# Altium schematic sheet 05_ATK_DLRK3506J_NET0.SchDoc
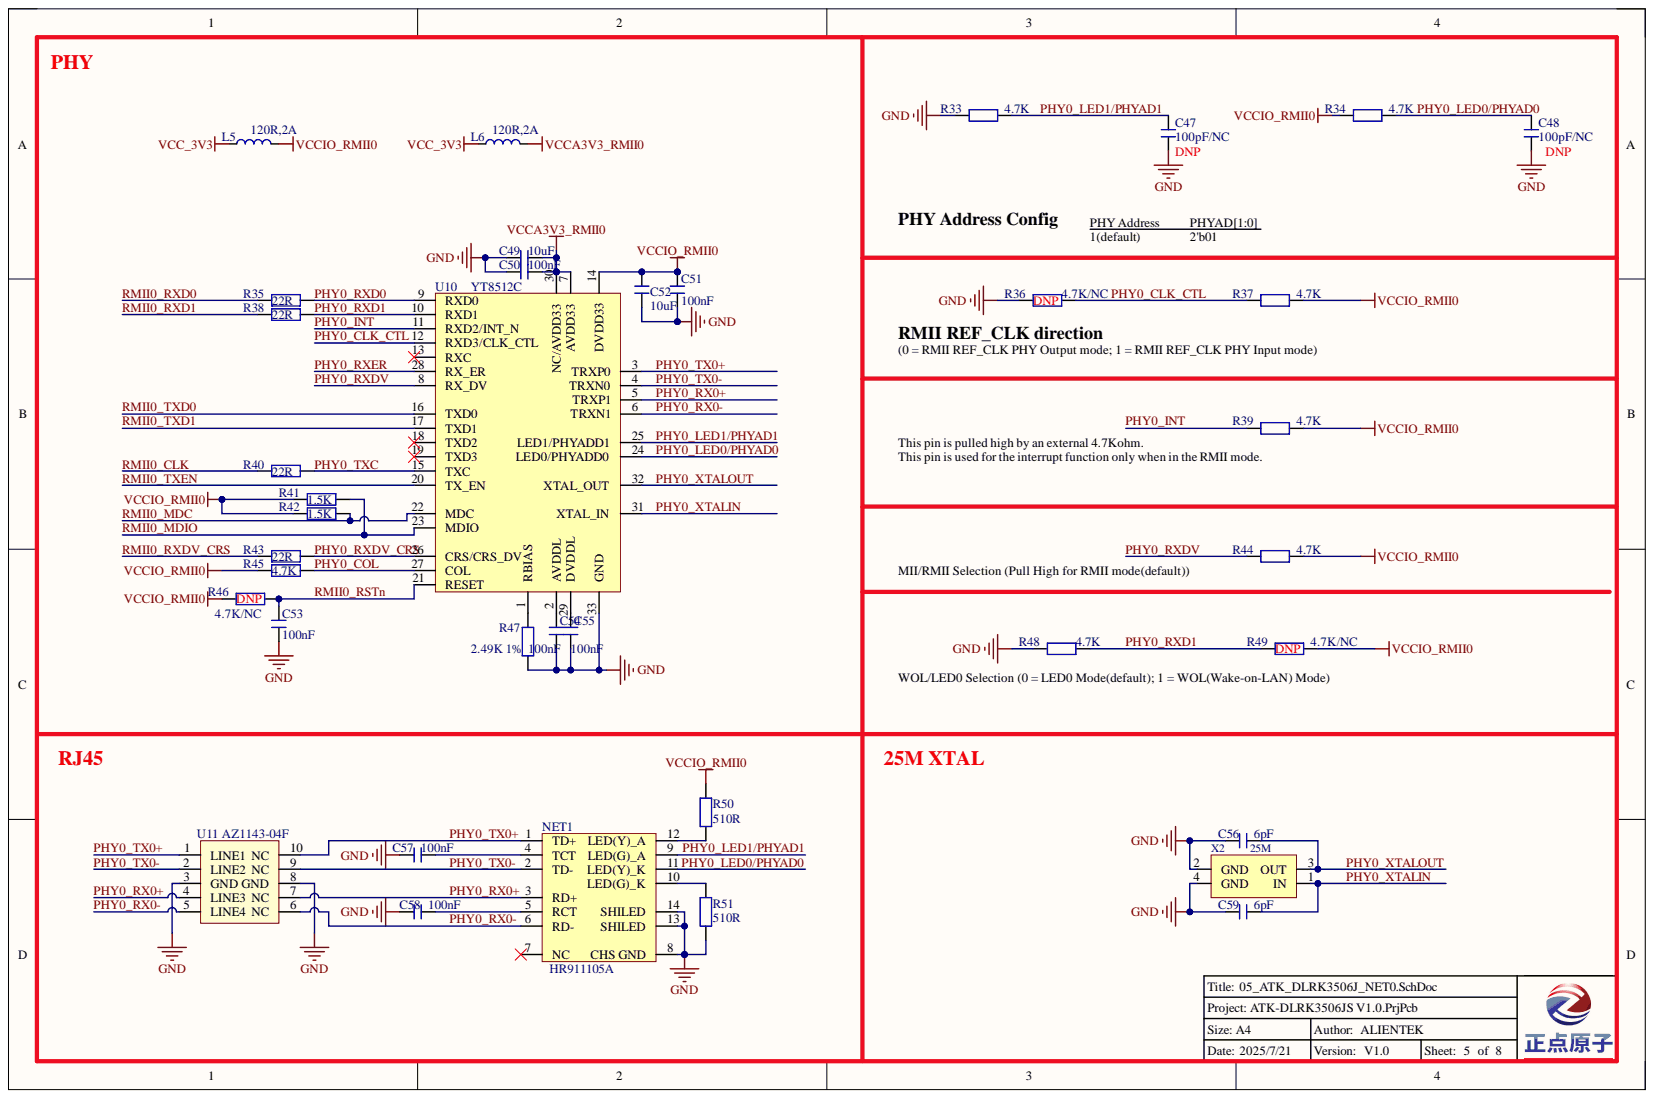
[diagram p0: 05_ATK_DLRK3506J_NET0.SchDoc]

## Parts
C47  100pF/NC  [C 0402]
C48  100pF/NC  [C 0402]
C49  10uF  [C 0402]
C50  100nF  [C 0402]
C51  100nF  [C 0402]
C52  10uF  [C 0402]
C53  100nF  [C 0402]
C54  100nF  [C 0402]
C55  100nF  [C 0402]
C56  6pF  [C 0402]
C57  100nF  [C 0402]
C58  100nF  [C 0402]
C59  6pF  [C 0402]
L5  120R,2A  [L 0603]
L6  120R,2A  [L 0603]
NET1  HR911105A  [HR911105A]
R33  4.7K  [R 0402]
R34  4.7K  [R 0402]
R35  22R  [R 0402]
R36  4.7K/NC  [R 0402]
R37  4.7K  [R 0402]
R38  22R  [R 0402]
R39  4.7K  [R 0402]
R40  22R  [R 0402]
R41  1.5K  [R 0402]
R42  1.5K  [R 0402]
R43  22R  [R 0402]
R44  4.7K  [R 0402]
R45  4.7K  [R 0402]
R46  4.7K/NC  [R 0402]
R47  2.49K 1%  [R 0402]
R48  4.7K  [R 0402]
R49  4.7K/NC  [R 0402]
R50  510R  [R 0402]
R51  510R  [R 0402]
U10  YT8512C  [YT8512C]
U11  AZ1143-04F  [DFN2510P10E]
X2  25M  [XTAL-3225]

## Nets
GND: C47.2 C48.2 C49.1 C50.2 C51.1 C52.2 C53.1 C54.1 C55.1 C56.2 C57.2 C58.2 C59.2 NET1.8(CHS_GND) NET1.13(SHILED) NET1.14(SHILED) R33.2 R36.2 R47.2 R48.2 R51.2 U10.33(GND) U11.3(GND) U11.8(GND) X2.2(GND) X2.4(GND)
VCC_3V3: L5.1 L6.1
VCCA3V3_RMII0: C49.2 C50.1 L6.2 U10.7(AVDD33) U10.30(NC/AVDD33)
VCCIO_RMII0: C51.2 C52.1 L5.2 R34.2 R37.1 R39.1 R41.2 R42.2 R44.1 R45.2 R46.2 R49.1 R50.2 U10.14(DVDD33)
PHY0_CLK_CTL: R36.1 R37.2 U10.12(RXD3/CLK_CTL)
PHY0_COL: R45.1 U10.27(COL)
PHY0_INT: R39.2 U10.11(RXD2/INT_N)
PHY0_LED0/PHYAD0: C48.1 NET1.11(LED(Y)_K) R34.1 U10.24(LED0/PHYADD0)
PHY0_LED1/PHYAD1: C47.1 NET1.9(LED(G)_A) R33.1 U10.25(LED1/PHYADD1)
PHY0_RX0+: NET1.3(RD+) U10.5(TRXP1) U11.4(LINE3) U11.7(NC)
PHY0_RX0-: NET1.6(RD-) U10.6(TRXN1) U11.5(LINE4) U11.6(NC)
PHY0_RXD0: R35.1 U10.9(RXD0)
PHY0_RXD1: R38.1 R48.1 R49.2 U10.10(RXD1)
PHY0_RXDV: R44.2 U10.8(RX_DV)
PHY0_RXDV_CRS: R43.1 U10.26(CRS/CRS_DV)
PHY0_RXER: U10.28(RX_ER)
PHY0_TX0+: NET1.1(TD+) U10.3(TRXP0) U11.1(LINE1) U11.10(NC)
PHY0_TX0-: NET1.2(TD-) U10.4(TRXN0) U11.2(LINE2) U11.9(NC)
PHY0_TXC: R40.1 U10.15(TXC)
PHY0_XTALIN: C59.1 U10.31(XTAL_IN) X2.1(IN)
PHY0_XTALOUT: C56.1 U10.32(XTAL_OUT) X2.3(OUT)
RMII0_CLK: R40.2
RMII0_MDC: R42.1 U10.22(MDC)
RMII0_MDIO: R41.1 U10.23(MDIO)
RMII0_RSTn: C53.2 R46.1 U10.21(RESET)
RMII0_RXD0: R35.2
RMII0_RXD1: R38.2
RMII0_RXDV_CRS: R43.2
RMII0_TXD0: U10.16(TXD0)
RMII0_TXD1: U10.17(TXD1)
RMII0_TXEN: U10.20(TX_EN)
NetC54_2: C54.2 U10.2(AVDDL)
NetC55_2: C55.2 U10.29(DVDDL)
NetC57_1: C57.1 NET1.4(TCT)
NetC58_1: C58.1 NET1.5(RCT)
NetNET1_10: NET1.10(LED(G)_K) R51.1
NetNET1_12: NET1.12(LED(Y)_A) R50.1
NetR47_1: R47.1 U10.1(RBIAS)

Not connected: NET1 7(NC); U10 13(RXC) 18(TXD2) 19(TXD3)

## Library data of the parts
C47-C59 = C: 无极性贴片电容; 版权归属: 源创客; BOM名称: 无极性贴片电容; Component Kind: Standard; Library Name: 电阻-电容-电感.SchLib; 学习资源: www.ooocke.com
L5 L6 = L: 小功率贴片电感磁珠; 版权归属: 正点原子; BOM名称: 小功率贴片电感; Component Kind: Standard; Library Name: 电阻-电容-电感.SchLib; 学习资源: www.openedv.com
NET1 = HR911105: Component Kind: Standard; Library Name: MYCOMLIB.IntLib
R33-R51 = R: 贴片电阻; BOM名称: 电阻; 版权归属: 正点原子; Component Kind: Standard; Library Name: 电阻-电容-电感.SchLib; 学习资源: www.openedv.com
U10 = YT8512C: 10M/100M 网络PHY RMII/MII; Component Kind: Standard; Library Name: ALIENTEK_COMPONENT.IntLib
U11 = AZ1143-04F: ESD保护二极管阵列; Component Kind: Standard; Library Name: 二极管-整流桥.SchLib
X2 = XTAL-4P: 4脚无源晶振; BOM名称: 4脚晶振; 版权归属: 源创客; Component Kind: Standard; 学习资源: www.ooocke.com; Library Name: Lycreturn.IntLib
